# Altium schematic sheet Connectors.SchDoc
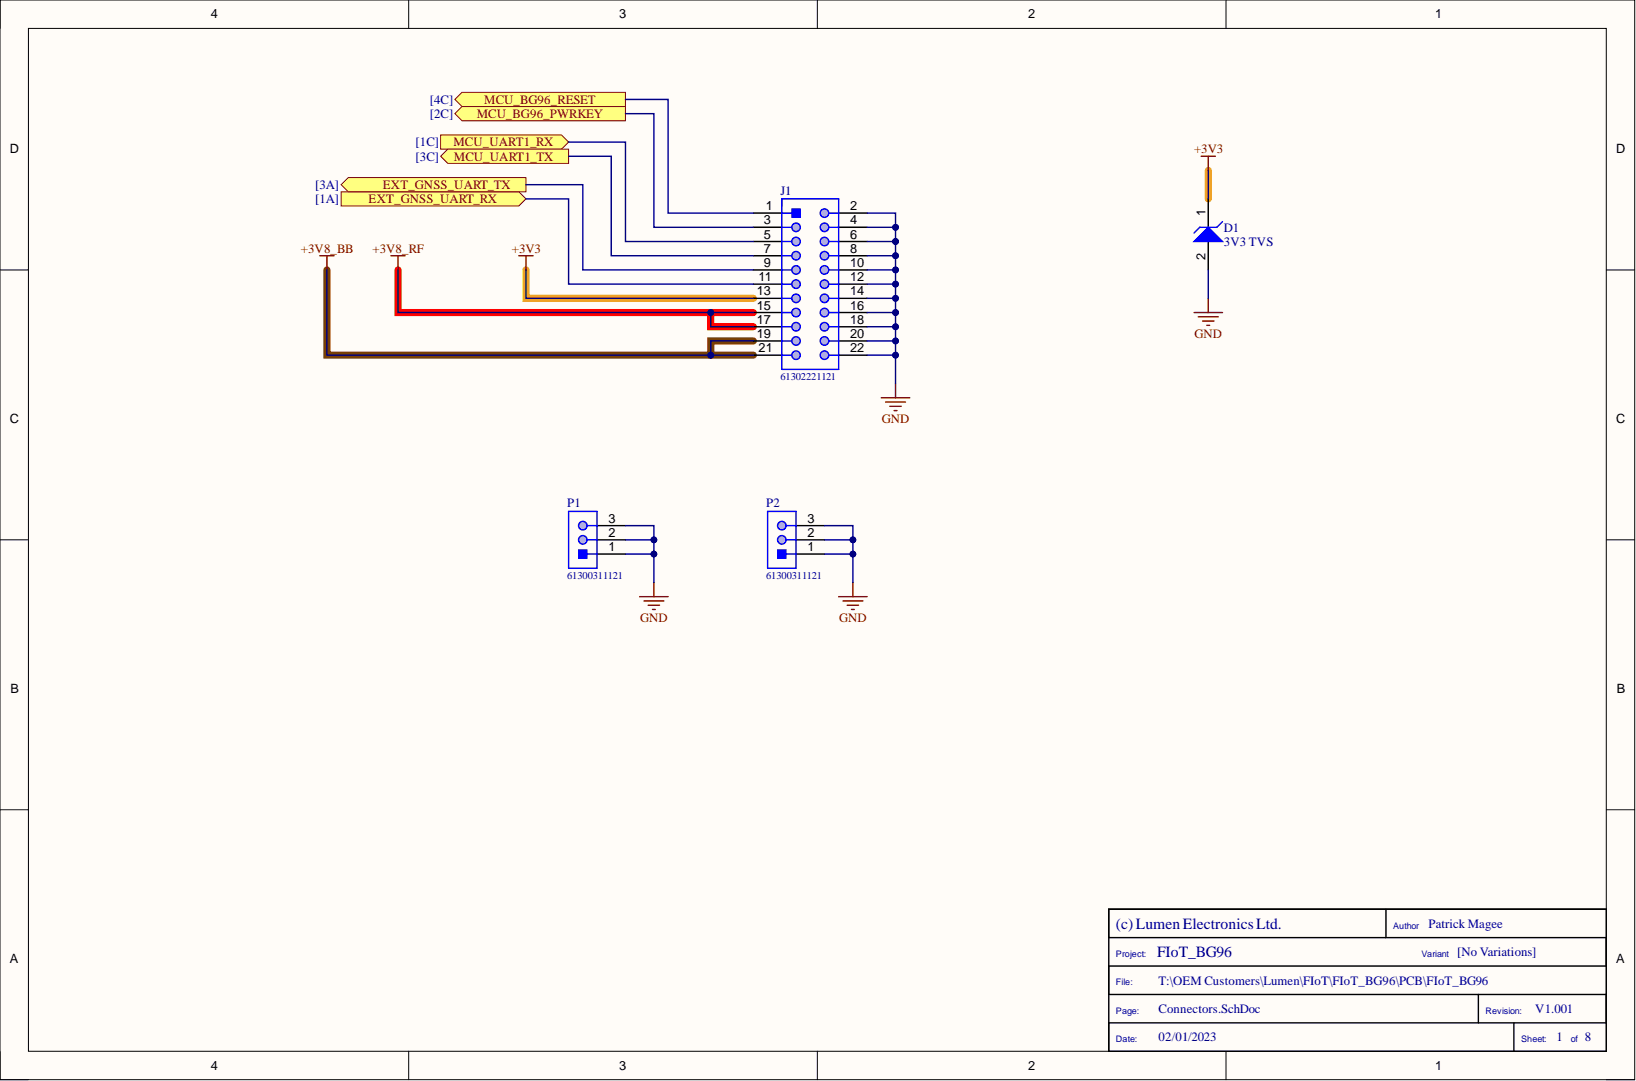
[diagram p0: Connectors.SchDoc]

## Parts
D1  value 3V3 TVS  [ONSC-SOD-923-2-514AA-01_V]  (hidden comment: ESD9X3.3ST5G)
J1  61302221121  [61302221121]
P1  61300311121  [61300311121]
P2  61300311121  [61300311121]

## Ports
EXT_GNSS_UART_RX, EXT_GNSS_UART_TX, MCU_BG96_PWRKEY, MCU_BG96_RESET, MCU_UART1_RX, MCU_UART1_TX

## Nets
+3V3: D1.1 J1.13
+3V8_BB: J1.19 J1.21
+3V8_RF: J1.15 J1.17
GND: D1.2 J1.2 J1.4 J1.6 J1.8 J1.10 J1.12 J1.14 J1.16 J1.18 J1.20 J1.22 P1.1 P1.2 P1.3 P2.1 P2.2 P2.3
EXT_GNSS_UART_RX: J1.11 ; port EXT_GNSS_UART_RX
EXT_GNSS_UART_TX: J1.9 ; port EXT_GNSS_UART_TX
MCU_BG96_PWRKEY: J1.3 ; port MCU_BG96_PWRKEY
MCU_BG96_RESET: J1.1 ; port MCU_BG96_RESET
MCU_UART1_RX: J1.5 ; port MCU_UART1_RX
MCU_UART1_TX: J1.7 ; port MCU_UART1_TX

## Library data of the parts
D1 = ESD9X3.3ST5G: Transient Voltage Suppressor, Micro-Packaged Diode for ESD Protection, 2-Pin SOD-923, Pb-Free, Tape and Reel; Manufacturer: On Semiconductor; Direction: Unidirectional; IR Max (uA): 2.5; Number of Lines: 1; V(BR) Min (V): 5; VRWM Max (V): 3.3; Component Kind: Standard; ComponentLink1Description: Manufacturer URL; ComponentLink1URL: http://www.onsemi.com/; ComponentLink2Description: Datasheet; ComponentLink2URL: http://www.onsemi.cn/pub_link/Collateral/ESD9X3.3ST5G-D.PDF; ComponentLink3Description: Package Specification; ComponentLink3URL: http://www.onsemi.com/pub_link/Collateral/514AA.PDF; DatasheetVersion: Rev. 7; JLC Footprint: SOD-923; JLCPCB Part #: C130456; Library Name: FIoT_BG96.SCHLIB; Manufacturer Part Number: ESD9X3.3ST5G; MFG Name: ON Semiconductor; MFG Part Number: ESD9X3.3ST5G; Mounting Technology: Surface Mount; PackageDescription: 2-Pin SOD, Body 0.8 x 0.6 mm; PackageReference: SOD-923-2-514AA-01; PackageVersion: Rev. E, 03/2011; Packing: Tape and Reel; PartNumber: ESD9X3.3ST5G; RoHS: Pb-Free; Supplier 1: Farnell; Supplier 2: Mouser; Supplier 3: LCSC; Supplier Part Number 1: 2627963; Supplier Part Number 2: 863-ESD9X3.3ST5G; Supplier Part Number 3: C130456
J1 = CMP-1502-01108-1: THT Vertical Pin Header WR-PHD, Pitch 2.54 mm, Dual Row, 22 pins; Manufacturer: Wurth Elektronik; Contact Resistance: 20mOhm; Gender: Male; Pin Number: 22; Pitch: 2.54mm; Rated Current: 3A; Withstanding Voltage: 500VAC; Working Voltage: 250VAC; Component Kind: Standard; Datasheet URL: https://katalog.we-online.de/em/datasheet/6130xx21121.pdf; Library Name: Altium Content Vault; Manufacturer URL: http://www.we-online.de; Mounting Technology: THT; Operating Temperature: -40to105degC; Orientation: Vertical; Package Reference: 61302221121; Packaging: Bag; Part Number: 61302221121; Row Number: Dual; Publisher: WurthElektronik
P1 P2 = CMP-1502-01062-1: THT Vertical Pin Header WR-PHD, Pitch 2.54 mm, Single Row, 3 pins; Manufacturer: Wurth Elektronik; Contact Resistance: 20mOhm; Gender: Male; Pin Number: 3; Pitch: 2.54mm; Rated Current: 3A; Withstanding Voltage: 500VAC; Working Voltage: 250VAC; Component Kind: Standard; Datasheet URL: https://katalog.we-online.de/em/datasheet/6130xx11121.pdf; Library Name: Altium Content Vault; Manufacturer URL: http://www.we-online.de; Mounting Technology: THT; Operating Temperature: -40to105degC; Orientation: Vertical; Package Reference: 61300311121; Packaging: Bag; Part Number: 61300311121; Row Number: Single; Publisher: WurthElektronik
